# Altium schematic sheet SENSING.SchDoc
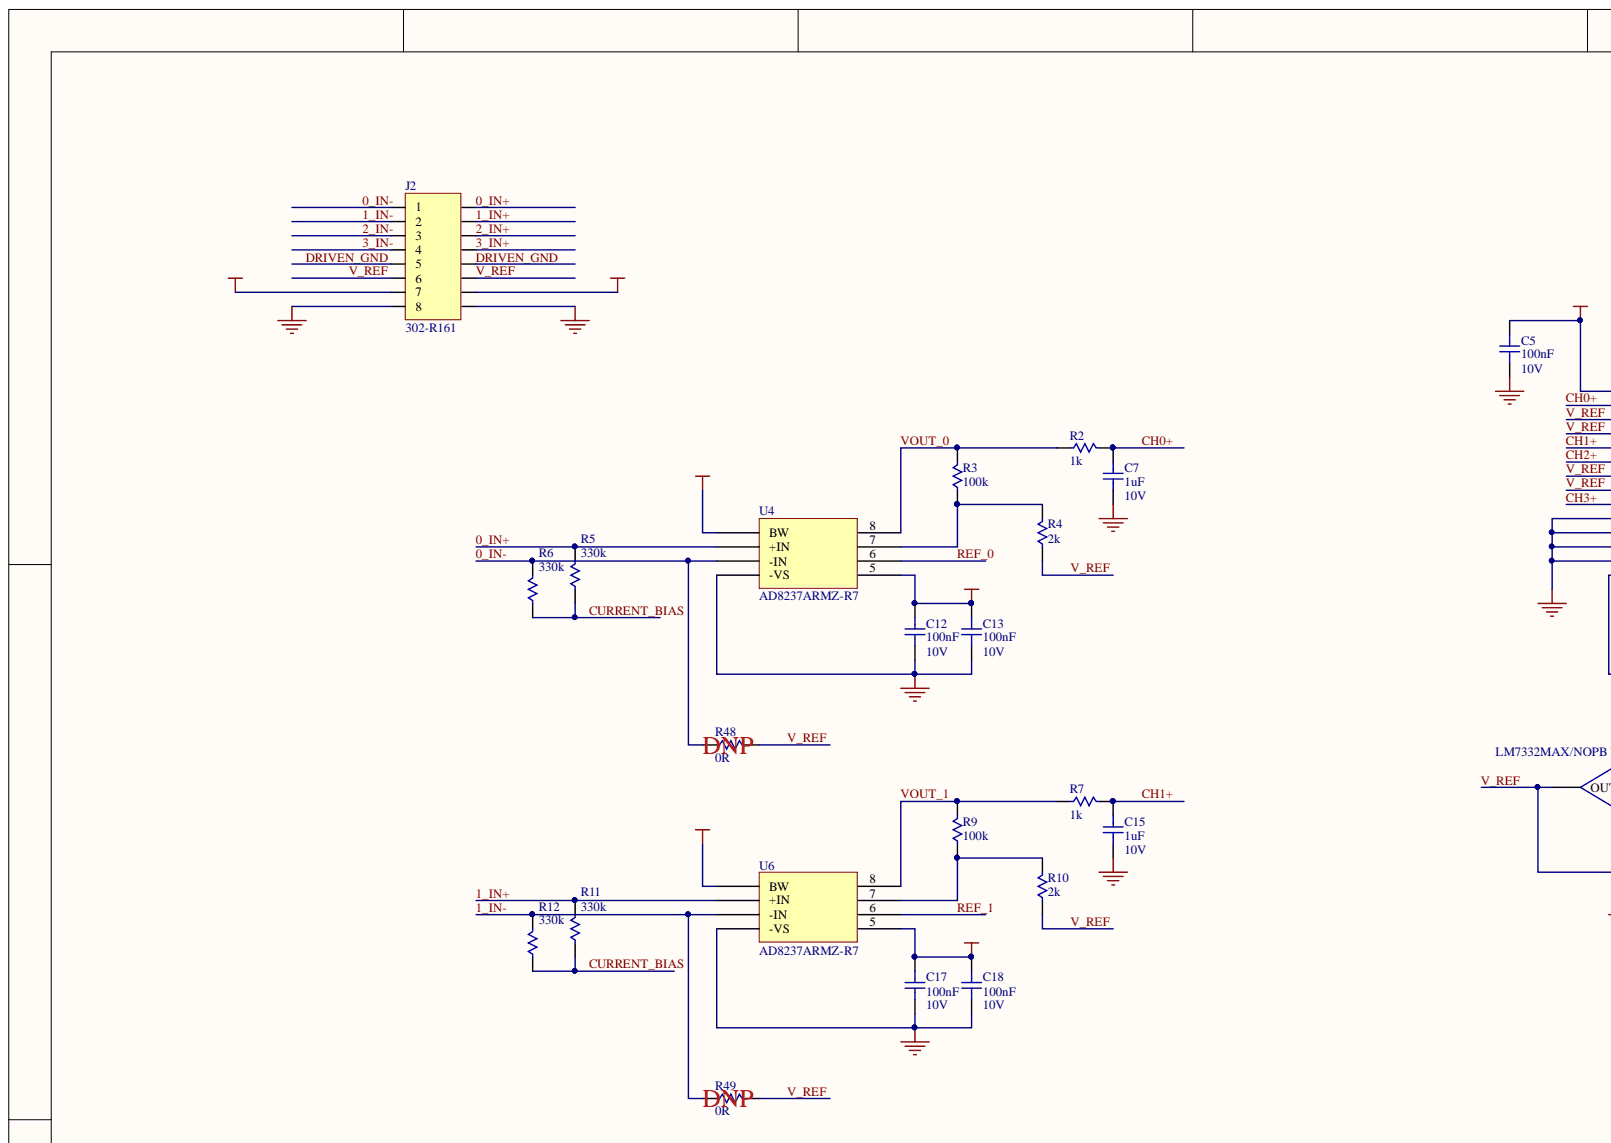
[diagram p0: SENSING.SchDoc, top left]
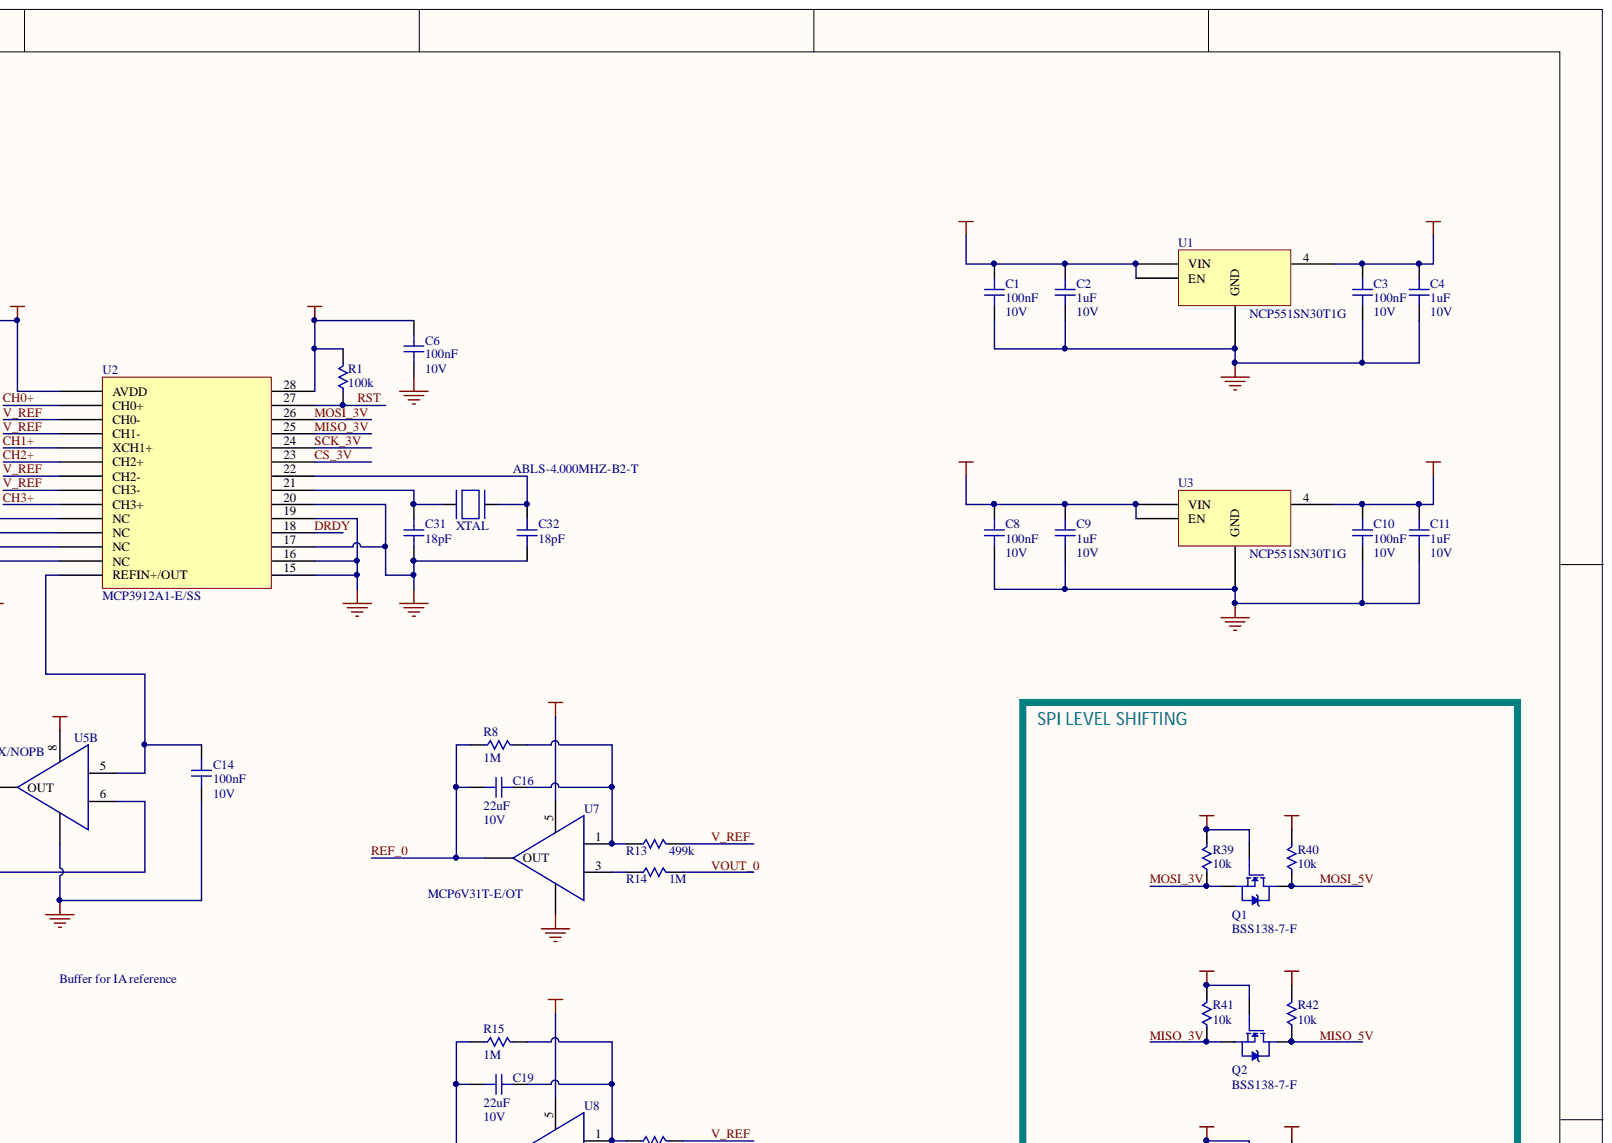
[diagram p1: SENSING.SchDoc, top right]
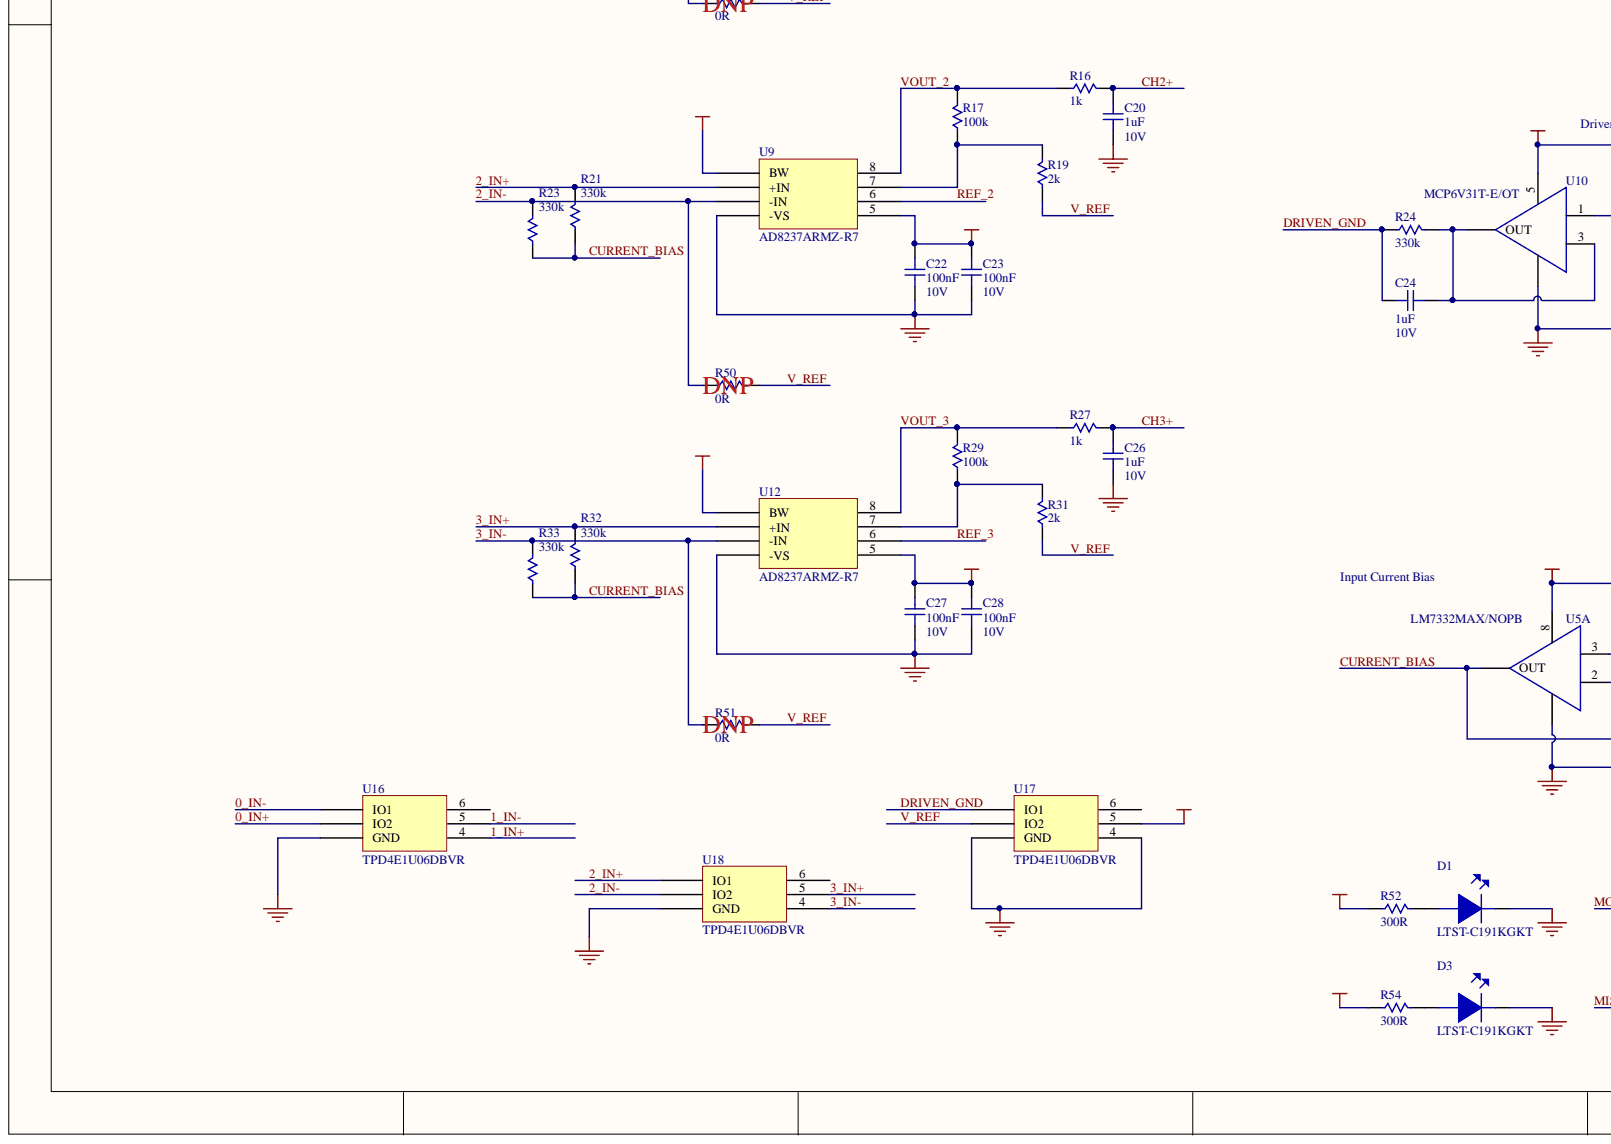
[diagram p2: SENSING.SchDoc, bottom left]
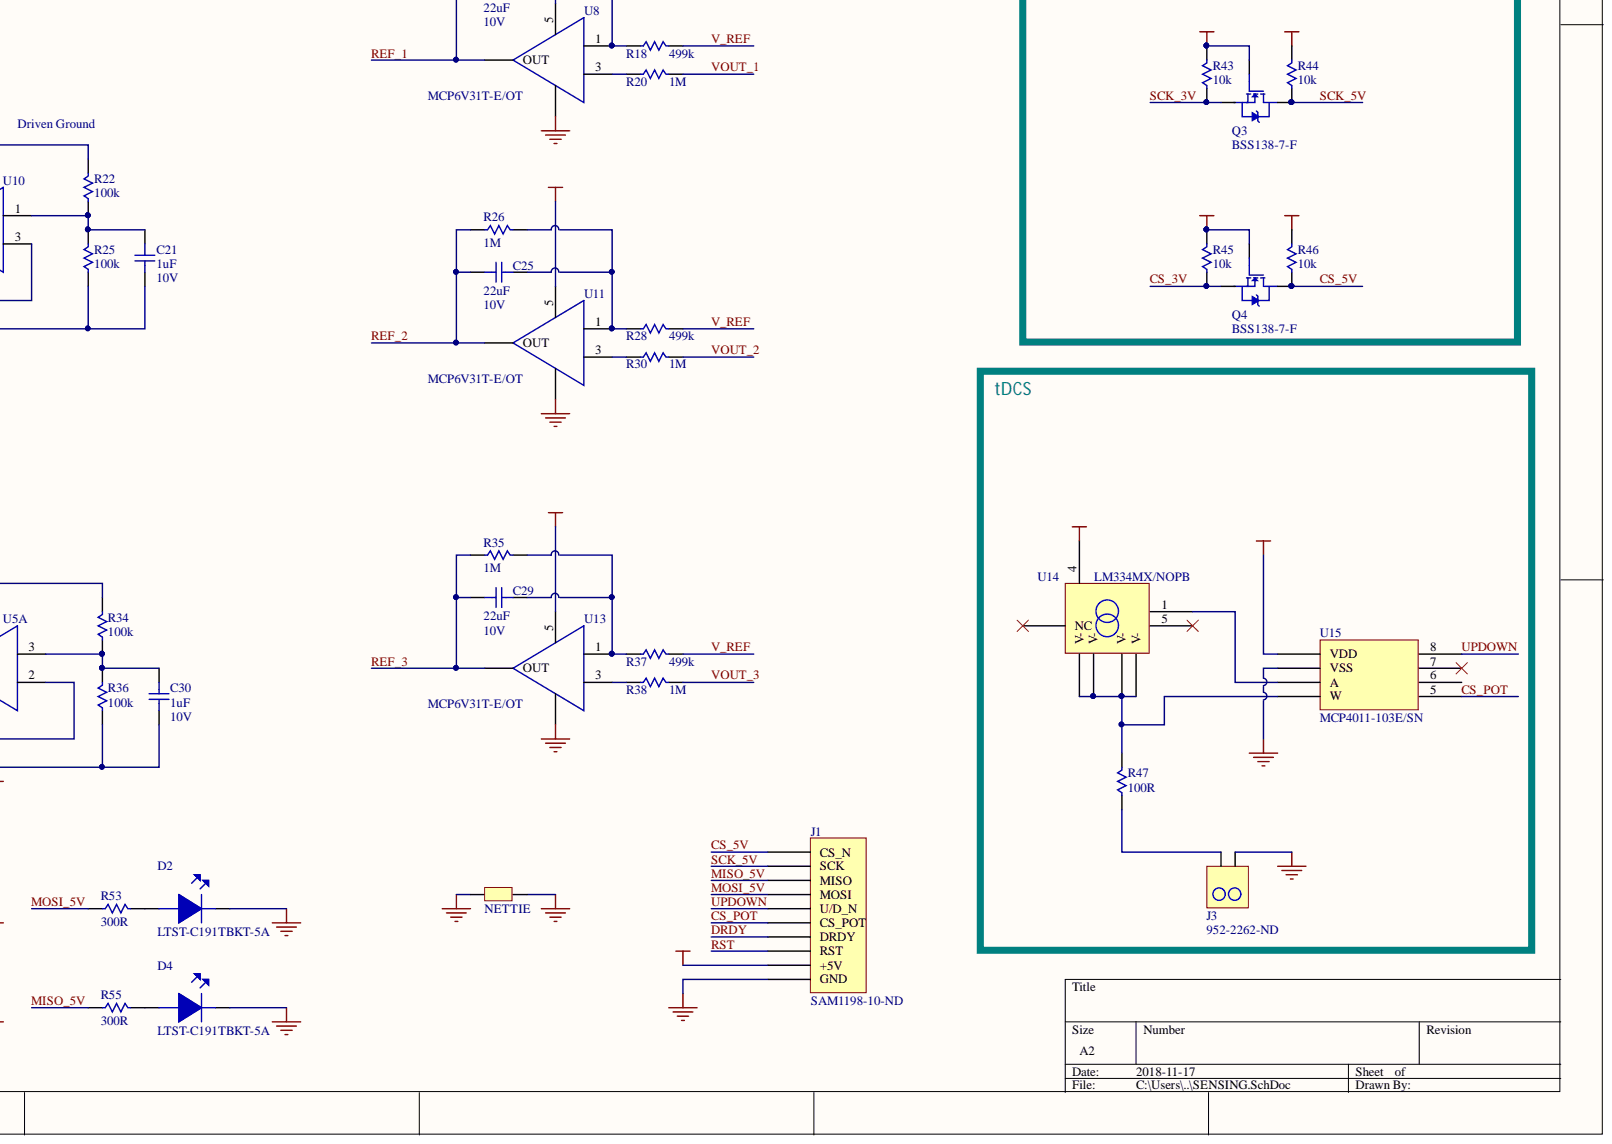
[diagram p3: SENSING.SchDoc, bottom right]

## Parts
C1  100nF  Voltage Rating: 10V  [C0402]
C2  1uF  Voltage Rating: 10V  [C0402]
C3  100nF  Voltage Rating: 10V  [C0402]
C4  1uF  Voltage Rating: 10V  [C0402]
C5  100nF  Voltage Rating: 10V  [C0402]
C6  100nF  Voltage Rating: 10V  [C0402]
C7  1uF  Voltage Rating: 10V  [C0402]
C8  100nF  Voltage Rating: 10V  [C0402]
C9  1uF  Voltage Rating: 10V  [C0402]
C10  100nF  Voltage Rating: 10V  [C0402]
C11  1uF  Voltage Rating: 10V  [C0402]
C12  100nF  Voltage Rating: 10V  [C0402]
C13  100nF  Voltage Rating: 10V  [C0402]
C14  100nF  Voltage Rating: 10V  [C0402]
C15  1uF  Voltage Rating: 10V  [C0402]
C16  22uF  [C0805]
C17  100nF  Voltage Rating: 10V  [C0402]
C18  100nF  Voltage Rating: 10V  [C0402]
C19  22uF  [C0805]
C20  1uF  Voltage Rating: 10V  [C0402]
C21  1uF  Voltage Rating: 10V  [C0402]
C22  100nF  Voltage Rating: 10V  [C0402]
C23  100nF  Voltage Rating: 10V  [C0402]
C24  1uF  Voltage Rating: 10V  [C0402]
C25  22uF  [C0805]
C26  1uF  Voltage Rating: 10V  [C0402]
C27  100nF  Voltage Rating: 10V  [C0402]
C28  100nF  Voltage Rating: 10V  [C0402]
C29  22uF  [C0805]
C30  1uF  Voltage Rating: 10V  [C0402]
C31  18pF  [C0402]
C32  18pF  [C0402]
D1  LTST-C191KGKT  [LED_0603]
D2  LTST-C191TBKT-5A  [LED_0603]
D3  LTST-C191KGKT  [LED_0603]
D4  LTST-C191TBKT-5A  [LED_0603]
J1  SAM1198-10-ND  [Header 10x1 8.5mm]
J2  302-R161  [CONN16POS]
J3  952-2262-ND  [2PIN_HEADER]
Q1  BSS138-7-F  [SOT23-3]
Q2  BSS138-7-F  [SOT23-3]
Q3  BSS138-7-F  [SOT23-3]
Q4  BSS138-7-F  [SOT23-3]
R1  100k  [R0402]
R2  1k  [R0402]
R3  100k  [R0402]
R4  2k  [R0402]
R5  330k  [R0402]
R6  330k  [R0402]
R7  1k  [R0402]
R8  1M  [R0402]
R9  100k  [R0402]
R10  2k  [R0402]
R11  330k  [R0402]
R12  330k  [R0402]
R13  499k  [R0402]
R14  1M  [R0402]
R15  1M  [R0402]
R16  1k  [R0402]
R17  100k  [R0402]
R18  499k  [R0402]
R19  2k  [R0402]
R20  1M  [R0402]
R21  330k  [R0402]
R22  100k  [R0402]
R23  330k  [R0402]
R24  330k  [R0402]
R25  100k  [R0402]
R26  1M  [R0402]
R27  1k  [R0402]
R28  499k  [R0402]
R29  100k  [R0402]
R30  1M  [R0402]
R31  2k  [R0402]
R32  330k  [R0402]
R33  330k  [R0402]
R34  100k  [R0402]
R35  1M  [R0402]
R36  100k  [R0402]
R37  499k  [R0402]
R38  1M  [R0402]
R39  10k  [R0402]
R40  10k  [R0402]
R41  10k  [R0402]
R42  10k  [R0402]
R43  10k  [R0402]
R44  10k  [R0402]
R45  10k  [R0402]
R46  10k  [R0402]
R47  100R  [R0805]
R48  0R  [R0402]
R49  0R  [R0402]
R50  0R  [R0402]
R51  0R  [R0402]
R52  300R  [R0402]
R53  300R  [R0402]
R54  300R  [R0402]
R55  300R  [R0402]
U1  NCP551SN30T1G  [TSOP-5]
U2  MCP3912A1-E/SS  [SSOP-28]
U3  NCP551SN30T1G  [TSOP-5]
U4  AD8237ARMZ-R7  [MSOP-8]
U5  LM7332MAX/NOPB  [8-SOIC]  (parts A,B)
U6  AD8237ARMZ-R7  [MSOP-8]
U7  MCP6V31T-E/OT  [SOT23-5]
U8  MCP6V31T-E/OT  [SOT23-5]
U9  AD8237ARMZ-R7  [MSOP-8]
U10  MCP6V31T-E/OT  [SOT23-5]
U11  MCP6V31T-E/OT  [SOT23-5]
U12  AD8237ARMZ-R7  [MSOP-8]
U13  MCP6V31T-E/OT  [SOT23-5]
U14  LM334MX/NOPB  [8-SOIC]
U15  MCP4011-103E/SN  [8-SOIC]
U16  TPD4E1U06DBVR  [SOT23-6]
U17  TPD4E1U06DBVR  [SOT23-6]
U18  TPD4E1U06DBVR  [SOT23-6]
XTAL  ABLS-4.000MHZ-B2-T  [XTAL]
  NETTIE  [NETTIE]

## Nets
+3V: C6.2 C10.2 C11.2 Q1.1 Q2.1 Q3.1 Q4.1 R1.2 R39.2 R41.2 R43.2 R45.2 R54.1 U2.28 U3.4
+3VA: C3.2 C4.2 C5.2 C12.2 C13.2 C17.2 C18.2 C22.2 C23.2 C27.2 C28.2 J2.7 J2.15 R22.2 R34.2 R52.1 U1.4 U2.1(AVDD) U4.1(BW) U4.5 U5.8 U6.1(BW) U6.5 U7.5 U8.5 U9.1(BW) U9.5 U10.5 U11.5 U12.1(BW) U12.5 U13.5 U17.5
+5V: C1.2 C2.2 C8.2 C9.2 J1.9(+5V) R40.2 R42.2 R44.2 R46.2 U1.1(VIN) U1.3(EN) U3.1(VIN) U3.3(EN) U14.4 U15.1(VDD)
AGND: C1.1 C2.1 C3.1 C4.1 C5.1 C7.1 C12.1 C13.1 C14.1 C15.1 C17.1 C18.1 C20.1 C21.1 C22.1 C23.1 C26.1 C27.1 C28.1 C30.1 D1.2 J2.8 J2.16 R25.1 R36.1 U1.2(GND) U2.10(NC) U2.11(NC) U2.12(NC) U2.13(NC) U2.15 U2.16 U2.19 U4.4(-VS) U5.4 U6.4(-VS) U7.2 U8.2 U9.4(-VS) U10.2 U11.2 U12.4(-VS) U13.2 U16.3(GND) U17.3(GND) U17.4 U18.3(GND) .1
GND: C6.1 C8.1 C9.1 C10.1 C11.1 C31.1 C32.1 D2.2 D3.2 D4.2 J1.10(GND) J3.2 U2.17 U2.20 U3.2(GND) U15.2(VSS) .2
0_IN+: J2.9 R5.2 U4.2(+IN) U16.2(IO2)
0_IN-: J2.1 R6.2 R48.1 U4.3(-IN) U16.1(IO1)
1_IN+: J2.10 R11.2 U6.2(+IN) U16.4
1_IN-: J2.2 R12.2 R49.1 U6.3(-IN) U16.5
2_IN+: J2.11 R21.2 U9.2(+IN) U18.1(IO1)
2_IN-: J2.3 R23.2 R50.1 U9.3(-IN) U18.2(IO2)
3_IN+: J2.12 R32.2 U12.2(+IN) U18.5
3_IN-: J2.4 R33.2 R51.1 U12.3(-IN) U18.4
CH0+: C7.2 R2.2 U2.2(CH0+)
CH1+: C15.2 R7.2 U2.5(XCH1+)
CH2+: C20.2 R16.2 U2.6(CH2+)
CH3+: C26.2 R27.2 U2.9(CH3+)
CS_3V: Q4.2 R45.1 U2.23
CS_5V: J1.1(CS_N) Q4.3 R46.1
CS_POT: J1.6(CS_POT) U15.5
CURRENT_BIAS: R5.1 R6.1 R11.1 R12.1 R21.1 R23.1 R32.1 R33.1 U5.1(OUT) U5.2
DRDY: J1.7(DRDY) U2.18
DRIVEN_GND: C24.2 J2.5 J2.13 R24.1 U17.1(IO1)
MISO_3V: Q2.2 R41.1 U2.25
MISO_5V: J1.3(MISO) Q2.3 R42.1 R55.1
MOSI_3V: Q1.2 R39.1 U2.26
MOSI_5V: J1.4(MOSI) Q1.3 R40.1 R53.1
REF_0: C16.1 R8.1 U4.6 U7.4(OUT)
REF_1: C19.1 R15.1 U6.6 U8.4(OUT)
REF_2: C25.1 R26.1 U9.6 U11.4(OUT)
REF_3: C29.1 R35.1 U12.6 U13.4(OUT)
RST: J1.8(RST) R1.1 U2.27
SCK_3V: Q3.2 R43.1 U2.24
SCK_5V: J1.2(SCK) Q3.3 R44.1
UPDOWN: J1.5(U/D_N) U15.8
V_REF: J2.6 J2.14 R4.1 R10.1 R13.2 R18.2 R19.1 R28.2 R31.1 R37.2 R48.2 R49.2 R50.2 R51.2 U2.3(CH0-) U2.4(CH1-) U2.7(CH2-) U2.8(CH3-) U5.6 U5.7(OUT) U17.2(IO2)
VOUT_0: R2.1 R3.2 R14.2 U4.8
VOUT_1: R7.1 R9.2 R20.2 U6.8
VOUT_2: R16.1 R17.2 R30.2 U9.8
VOUT_3: R27.1 R29.2 R38.2 U12.8
NetC14_2: C14.2 U2.14(REFIN+/OUT) U5.5
NetC16_2: C16.2 R8.2 R13.1 U7.1
NetC19_2: C19.2 R15.2 R18.1 U8.1
NetC21_2: C21.2 R22.1 R25.2 U10.1
NetC24_1: C24.1 R24.2 U10.3 U10.4(OUT)
NetC25_2: C25.2 R26.2 R28.1 U11.1
NetC29_2: C29.2 R35.2 R37.1 U13.1
NetC30_2: C30.2 R34.1 R36.2 U5.3
NetC31_2: C31.2 U2.21 XTAL.1
NetC32_2: C32.2 U2.22 XTAL.2
NetD1_1: D1.1 R52.2
NetD2_1: D2.1 R53.2
NetD3_1: D3.1 R54.2
NetD4_1: D4.1 R55.2
NetJ3_1: J3.1 R47.1
NetR3_1: R3.1 R4.2 U4.7
NetR9_1: R9.1 R10.2 U6.7
NetR14_1: R14.1 U7.3
NetR17_1: R17.1 R19.2 U9.7
NetR20_1: R20.1 U8.3
NetR29_1: R29.1 R31.2 U12.7
NetR30_1: R30.1 U11.3
NetR38_1: R38.1 U13.3
NetR47_2: R47.2 U14.2(V-) U14.3(V-) U14.6(V-) U14.7(V-) U15.4(W)
NetU14_1: U14.1 U15.3(A)

Not connected: U14 5 8(NC); U15 6 7; U16 6; U17 6; U18 6
